# Altium schematic sheet Amp_op1.SchDoc(Amp_op1)
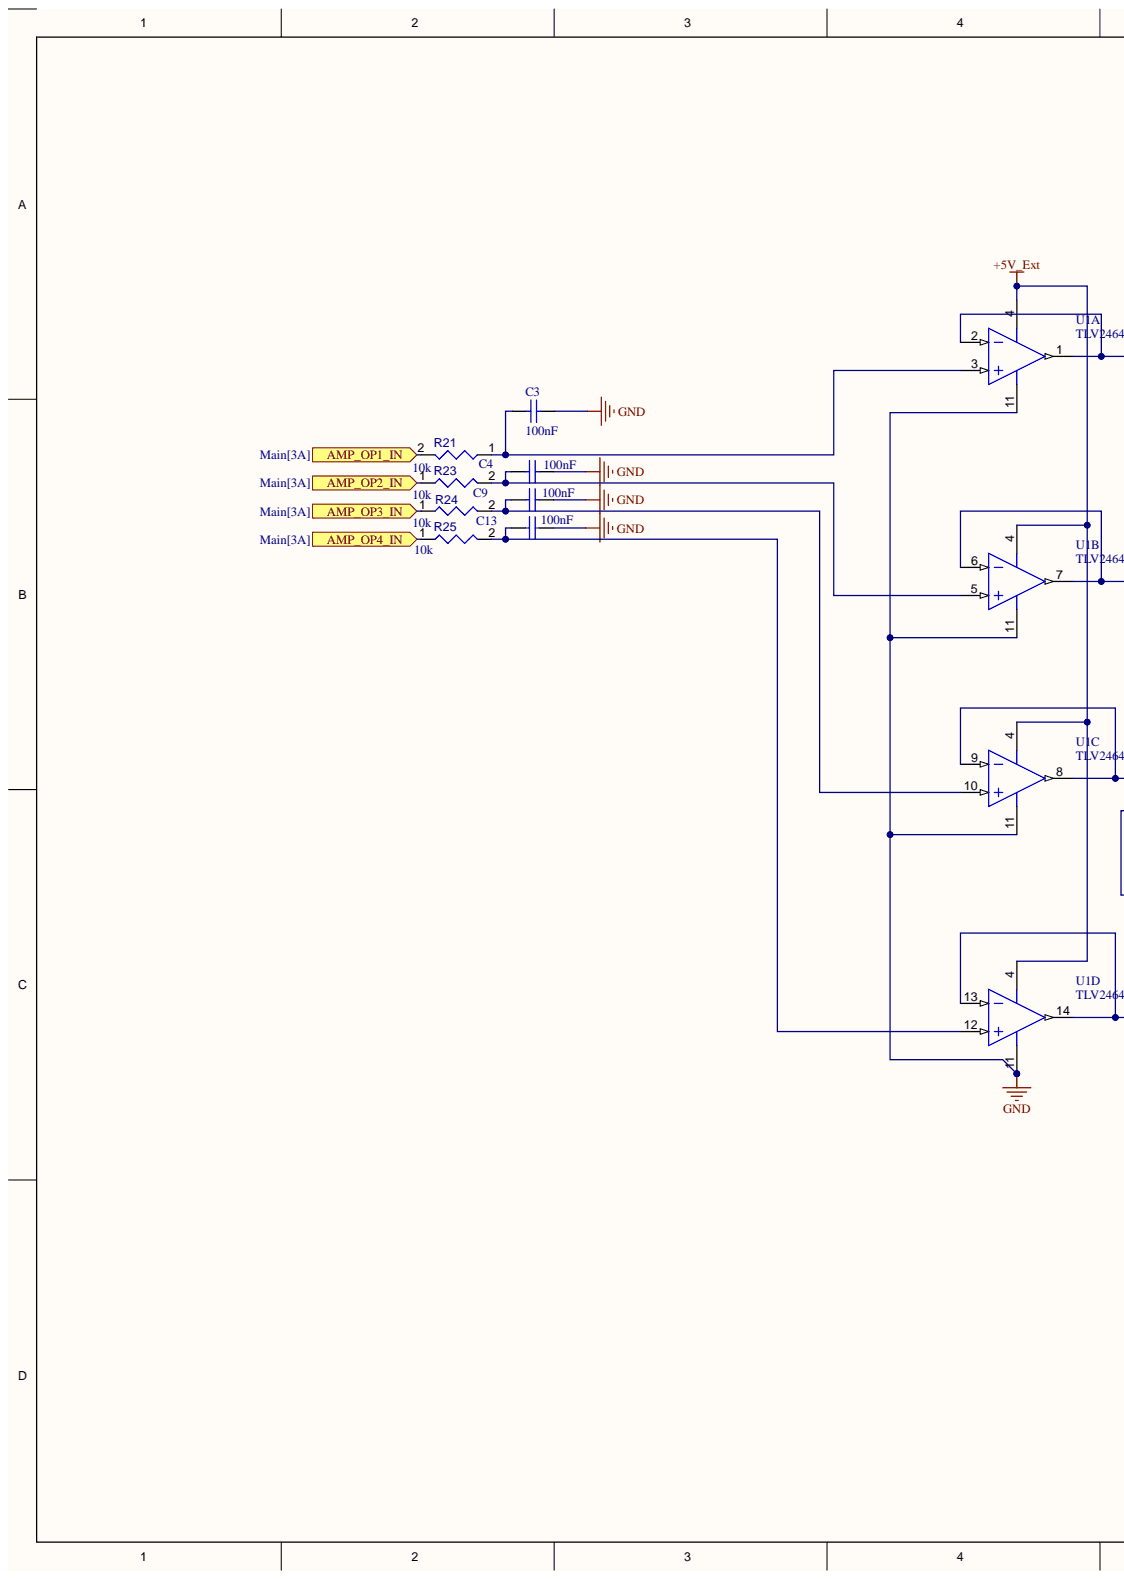
[diagram p0: Amp_op1.SchDoc, left]
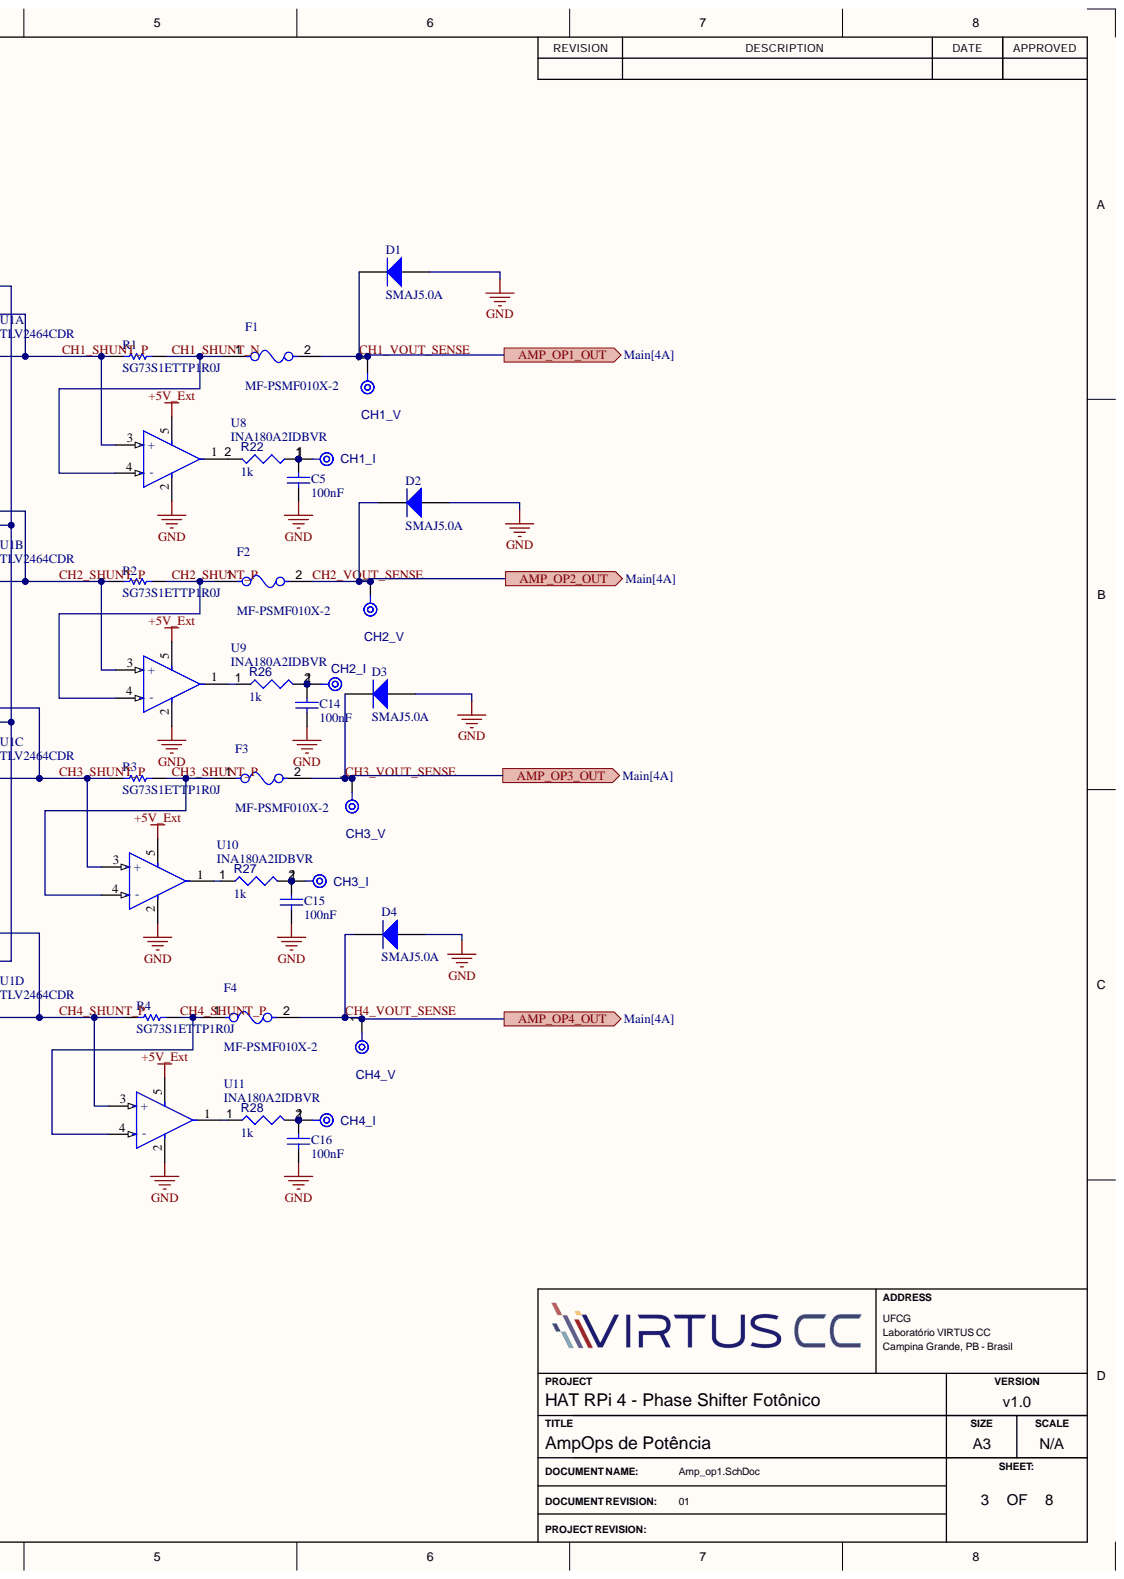
[diagram p1: Amp_op1.SchDoc, right]

## Parts
C3  value 100nF  [CAPC0603(1608)100_L]  (hidden comment: VJ0603Y104MXJCW1BC)
C4  value 100nF  [CAPC0603(1608)100_L]  (hidden comment: VJ0603Y104MXJCW1BC)
C5  value 100nF  [CAPC0603(1608)100_L]  (hidden comment: VJ0603Y104MXJCW1BC)
C9  value 100nF  [CAPC0603(1608)100_L]  (hidden comment: VJ0603Y104MXJCW1BC)
C13  value 100nF  [CAPC0603(1608)100_L]  (hidden comment: VJ0603Y104MXJCW1BC)
C14  value 100nF  [CAPC0603(1608)100_L]  (hidden comment: VJ0603Y104MXJCW1BC)
C15  value 100nF  [CAPC0603(1608)100_L]  (hidden comment: VJ0603Y104MXJCW1BC)
C16  value 100nF  [CAPC0603(1608)100_L]  (hidden comment: VJ0603Y104MXJCW1BC)
CH1_I  TPAD6R  [TP06R]  (value not drawn)  (no BOM)
CH1_V  TPAD6R  [TP06R]  (value not drawn)  (no BOM)
CH2_I  TPAD6R  [TP06R]  (value not drawn)  (no BOM)
CH2_V  TPAD6R  [TP06R]  (value not drawn)  (no BOM)
CH3_I  TPAD6R  [TP06R]  (value not drawn)  (no BOM)
CH3_V  TPAD6R  [TP06R]  (value not drawn)  (no BOM)
CH4_I  TPAD6R  [TP06R]  (value not drawn)  (no BOM)
CH4_V  TPAD6R  [TP06R]  (value not drawn)  (no BOM)
D1  SMAJ5.0A  [BOUR-SMA-2_V]
D2  SMAJ5.0A  [BOUR-SMA-2_V]
D3  SMAJ5.0A  [BOUR-SMA-2_V]
D4  SMAJ5.0A  [BOUR-SMA-2_V]
F1  MF-PSMF010X-2  [FP-MF-PSMF-MFG]
F2  MF-PSMF010X-2  [FP-MF-PSMF-MFG]
F3  MF-PSMF010X-2  [FP-MF-PSMF-MFG]
F4  MF-PSMF010X-2  [FP-MF-PSMF-MFG]
R1  SG73S1ETTP1R0J  [RESC1005X40X25LL10T10]
R2  SG73S1ETTP1R0J  [RESC1005X40X25LL10T10]
R3  SG73S1ETTP1R0J  [RESC1005X40X25LL10T10]
R4  SG73S1ETTP1R0J  [RESC1005X40X25LL10T10]
R21  value 10k  [RESC0603(1608)_L]  (hidden comment: Resistor 10k +/-1% 0603 100 mW)
R22  value 1k  [RESC0603(1608)_L]  (hidden comment: Resistor 1k +/-1% 0603 100 mW)
R23  value 10k  [RESC0603(1608)_L]  (hidden comment: Resistor 10k +/-1% 0603 100 mW)
R24  value 10k  [RESC0603(1608)_L]  (hidden comment: Resistor 10k +/-1% 0603 100 mW)
R25  value 10k  [RESC0603(1608)_L]  (hidden comment: Resistor 10k +/-1% 0603 100 mW)
R26  value 1k  [RESC0603(1608)_L]  (hidden comment: Resistor 1k +/-1% 0603 100 mW)
R27  value 1k  [RESC0603(1608)_L]  (hidden comment: Resistor 1k +/-1% 0603 100 mW)
R28  value 1k  [RESC0603(1608)_L]  (hidden comment: Resistor 1k +/-1% 0603 100 mW)
U1  TLV2464CDR  [D0014A_N]  (parts A,B,C,D)
U8  INA180A2IDBVR  [FP-DBV0005A-MFG]
U9  INA180A2IDBVR  [FP-DBV0005A-MFG]
U10  INA180A2IDBVR  [FP-DBV0005A-MFG]
U11  INA180A2IDBVR  [FP-DBV0005A-MFG]

## Ports
AMP_OP1_IN, AMP_OP1_OUT, AMP_OP2_IN, AMP_OP2_OUT, AMP_OP3_IN, AMP_OP3_OUT, AMP_OP4_IN, AMP_OP4_OUT

## Nets
+5V_Ext: U1.4 U8.5 U9.5 U10.5 U11.5
GND: C3.2 C4.2 C5.1 C9.2 C13.2 C14.1 C15.1 C16.1 D1.1 D2.1 D3.1 D4.1 U1.11 U8.2 U9.2 U10.2 U11.2
AMP_OP1_IN: R21.2 ; port AMP_OP1_IN
AMP_OP2_IN: R23.1 ; port AMP_OP2_IN
AMP_OP3_IN: R24.1 ; port AMP_OP3_IN
AMP_OP4_IN: R25.1 ; port AMP_OP4_IN
CH1_SHUNT_N: F1.1 R1.2 U8.4(-)
CH1_SHUNT_P: R1.1 U1.1 U1.2 U8.3(+)
CH1_VOUT_SENSE: CH1_V.1 D1.2 F1.2 ; port AMP_OP1_OUT
CH2_SHUNT_P: F2.1 R2.1 R2.2 U1.6 U1.7 U9.3(+) U9.4(-)
CH2_VOUT_SENSE: CH2_V.1 D2.2 F2.2 ; port AMP_OP2_OUT
CH3_SHUNT_P: F3.1 R3.1 R3.2 U1.8 U1.9 U10.3(+) U10.4(-)
CH3_VOUT_SENSE: CH3_V.1 D3.2 F3.2 ; port AMP_OP3_OUT
CH4_SHUNT_P: F4.1 R4.1 R4.2 U1.13 U1.14 U11.3(+) U11.4(-)
CH4_VOUT_SENSE: CH4_V.1 D4.2 F4.2 ; port AMP_OP4_OUT
NetC3_1: C3.1 R21.1 U1.3
NetC4_1: C4.1 R23.2 U1.5
NetC5_2: C5.2 CH1_I.1 R22.1
NetC9_1: C9.1 R24.2 U1.10
NetC13_1: C13.1 R25.2 U1.12
NetC14_2: C14.2 CH2_I.1 R26.2
NetC15_2: C15.2 CH3_I.1 R27.2
NetC16_2: C16.2 CH4_I.1 R28.2
NetR22_2: R22.2 U8.1
NetR26_1: R26.1 U9.1
NetR27_1: R27.1 U10.1
NetR28_1: R28.1 U11.1

## Library data of the parts
C3-C5 C9 C13-C16 = CMP-001-00085-1: Multilayer Ceramic Capacitor, 0.1 uF, 16 V, ± 20%, X7R, 0603 [1608 Metric]; Manufacturer: Vishay Vitramon; Dielectric Material: Tantalum; Voltage Rating: 16V; Case Code (Imperial): 0603; Case Code (Metric): 1608; Case/Package: 0603; Component Kind: Standard; Component Type: Capacitors; Construction: Flat; Depth: 0.8mm; Dielectric: X7R; Height: 0.95mm; Lead Free: Lead Free; Length: 1.6mm; Manufacturer Part Number: VJ0603Y104MXJCW1BC; Max Operating Temperature: 125°C; Min Operating Temperature: -55°C; Mounting Technology: Surface Mount; Package Quantity: 4000; Packaging: Tape and Reel; RoHS Compliant: Yes; Series: VJ; Termination: SMD/SMT; Tolerance: 20%; Voltage Rating (DC): 16V; Width: 0.8mm; Library Name: PCEIOT.SCHLIB
CH1_I CH1_V CH2_I CH2_V CH3_I CH3_V CH4_I CH4_V = CMP-00028-00002-2: 0.6mm round; Component Type: Mechanical; Pins: 1; Library Name: PCEIOT.SCHLIB
D1-D4 = CMP-2000-07090-1: SMAJ Transient Voltage Suppressor Diode Series, 3.5 V, -55 to 150 degC, 2-Pin SMA, RoHS, Tape and Reel; Manufacturer: Bourns; Catalog Drawings: SMAJSeriesFootprintSMAJ,SMBJSeries; Current - Peak Pulse (10/1000µs): 43.5A; EDA / CAD Models: DownloadfromAcceleratedDesigns; Featured Product: SMAJ/SMBJ/SMCJ/SMLJDiscreteTVSDiodes; Online Catalog: SMAJSeries; Other Names: SMAJ5.0ABDKR; Power - Peak Pulse: 400W; Power Line Protection: No; Type: Zener; Unidirectional Channels: 1; Voltage - Breakdown (Min): 6.4V; Voltage - Clamping (Max) @ Ipp: 9.2V; Voltage - Reverse Standoff (Typ): 5V; Applications: GeneralPurpose; Bidirectional Channels: -; Capacitance @ Frequency: -; Category: CircuitProtection; Component Kind: Standard; Datasheet URL: https://datasheet.ciiva.com/25624/0900766b8112213b-25624680.pdf; Datasheet Version: 08/2012; Family: TVS-Diodes; Library Name: Altium Content Vault; Manufacturer URL: http://www.bourns.com/; Mounting Technology: SurfaceMount; Mounting Type: SurfaceMount; Operating Temperature: -55°C~150°C(TJ); Package / Case: DO-214AC,SMA; Package Description: 2-Pin Surface Mount Device, Body 4.315 x 2.605 mm; Package Reference: SMA-2; Packaging: Digi-Reel®; RoHS Information: SMAJSeriesMaterialDeclaration; Series: SMAJ; Standard Package: 1; Supplier Device Package: SMA(DO-214AC)
F1-F4 = CMP-07400-000017-1: PTC RESET FUSE 15V 100MA 0805; Manufacturer: Bourns Inc.; Current Rating: 40A; Hold Current: 0.1A; Resistance: 1-7.5Ω; Trip Current: 0.3A; Trip Time: 1.5s; Value: 1-7.5Ω; Ambient Temperature Range High: +85°C; Ambient Temperature Range Low: -40°C; Automotive: No; Category: Fuse; Component Kind: Standard; ComponentLink2Description: Datasheet; ComponentLink2URL: https://www.bourns.com/docs/Product-Datasheets/mfpsmf.pdf; Device Class L1: Circuit Protection; Device Class L2: PTC Resettable Fuses; Device Class L3: unset; Digikey Description: PTC RESET FUSE 15V 100MA 0805; Height: 0.85mm; Ipc Land Pattern Name: CAPC215135X67; Lead Free: Yes; Library Name: Altium Content Vault; Manufacturer Part Number: MF-PSMF010X-2; Mouser Description: Resettable Fuses - PPTC 0.10A 15V 1ohm; Octopart: https://octopart.com/mf-psmf010x-2-bourns-7483441; Package: MF-PSMF; RoHS: TRUE; Temperature: -40°C to +85°C; Temperature Range High: +85°C; Temperature Range Low: -40°C; Voltage Rating: 15V
R1-R4 = CMP-2002-04124-1: Manufacturer: KOA Speer; Component Kind: Standard; Library Name: Altium Content Vault; Part Number: SG73S1ETTP1R0J
R21 R23-R25 = CMP-009-00017-7: Chip Resistor, 10 KOhm, +/- 1%, 01 W, -55 to 155 degC, 0603 (1608 Metric); Manufacturer: Yageo; Manufacturer Part Number: RC0603FR-0710KL; Power: 100mW; Voltage Rating: 50V; Case/Package: 0603; Component Kind: Standard; Component Type: Resistors; Max Operating Temperature: 155°C; Min Operating Temperature: -55°C; Mounting Technology: SM; Pins: 2; RoHS Compliant: True; Tolerance: 1%; Library Name: PCEIOT.SCHLIB
R22 R26-R28 = CMP-009-00014-6: Chip Resistor, 1 KOhm, +/-1%, 0.1 W, -55 to 155 degC, 0603 (1608 Metric); Manufacturer: Yageo; Manufacturer Part Number: RC0603FR-071KL; Power: 100mW; Tolerance: 1%; Voltage Rating: 50V; Case/Package: 0603; Component Kind: Standard; Component Type: Resistors; Max Operating Temperature: 155°C; Min Operating Temperature: -55°C; Mounting Technology: SM; Pins: 2; RoHS Compliant: True; Library Name: PCEIOT.SCHLIB
U1 = CMP-0271-00006-3: Quad Low Power, Rail-to-Rail Input / Output Operational Amplifier, 2.7 to 6 V, 0 to 70 degC, 14-pin SOIC (D14), Green (RoHS & no Sb/Br); Manufacturer: Texas Instruments; CMRR(Min)(dB): 66; IIB(Max)(pA): 14000; Iq per channel(Max)(mA): 0.575; Number of Channels: 4; Offset Drift(Typ)(uV/C): 2; Slew Rate(Typ)(V/us): 1.6; Sub Family: High Output Current Amplifier (>=50mA), Low Supply Voltage Amplifier (<=2.7V), Precision Amplifier; Total Supply Voltage(Max)(+5V is 5, +/-5V is 10): 6; Total Supply Voltage(Min)(+5V is 5, +/-5V is 10): 2.7; Vn at 1kHz(Typ)(nV/rtHz): 11; Code_JEDEC: MS-012; Component Kind: Standard; ComponentLink1Description: Manufacturer URL; ComponentLink1URL: http://www.ti.com/; ComponentLink2Description: Datasheet; ComponentLink2URL: http://www.ti.com/general/docs/lit/getliterature.tsp?genericPartNumber=TLV2464&fileType=pdf; ComponentLink3Description: Package Specification; ComponentLink3URL: http://www.ti.com/lit/ml/mpds177g/mpds177g.pdf; DatasheetVersion: SLOS220J; Eco-Plan: Green (RoHS & no Sb/Br); Library Name: Altium Content Vault; Mounting Technology: Surface Mount; PackageDescription: 14-Pin Plastic Small Outline, Body 8.65 x 3.9 mm, Pitch 1.27 mm; PackageReference: D0014A; PackageVersion: 4040047-5/M, 06/2011; PartNumber: TLV2464CDR; Vio (25C)(Max)(mV): 2
U8-U11 = CMP-04895-000287-1: IC CURR SENSE 1 CIRCUIT SOT23-5; -3db Bandwidth: 350kHz; Input Bias Current: 100nA; Input Offset Voltage (Vos): 100uV; Number of Circuits: 1; Operating Supply Current: 260uA; Slew Rate: 2V/µs; Case/Package: SOT-23-5; Component Kind: Standard; Library Name: Altium Content Vault; Max Operating Temperature: 125°C; Min Operating Temperature: -40°C; Mount: Surface Mount; Packaging: Tape and Reel; RoHS Compliant: Yes
